# Altium schematic sheet Nixies.SchDoc(Nixies)
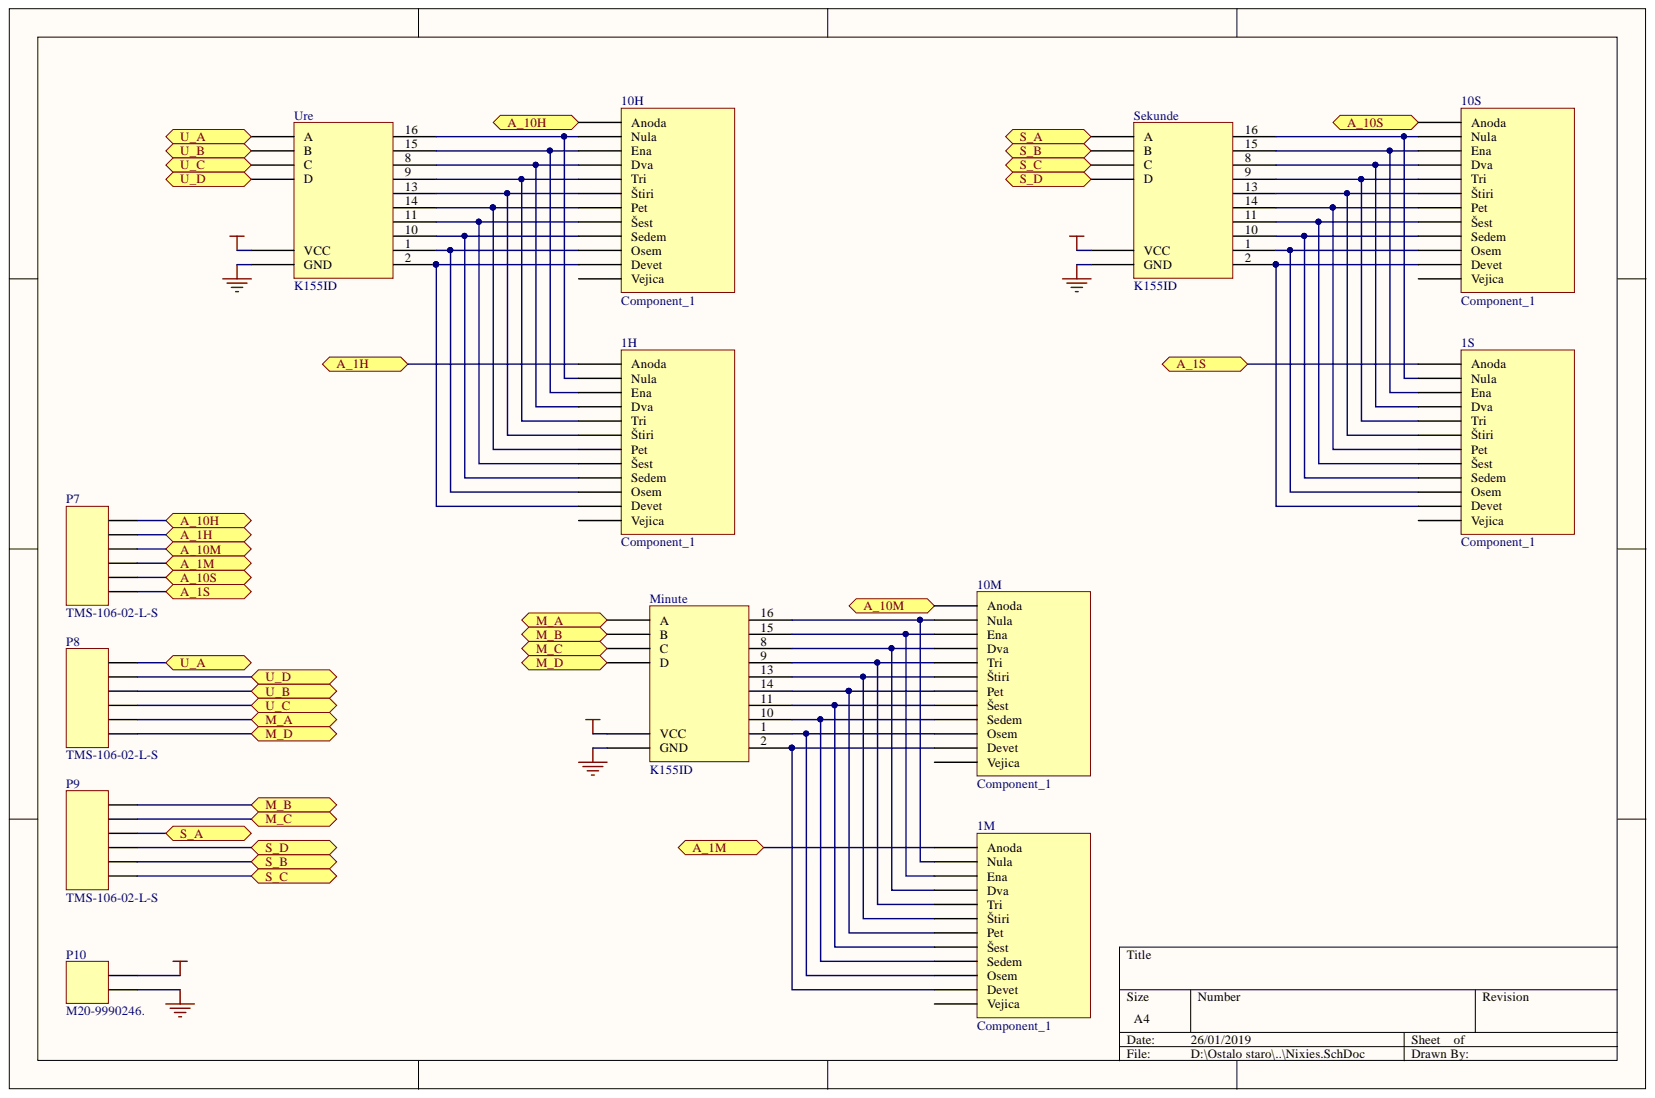
[diagram p0: Nixies.SchDoc]

## Parts
1H  Component_1  [nixie]
1M  Component_1  [nixie]
1S  Component_1  [nixie]
10H  Component_1  [nixie]
10M  Component_1  [nixie]
10S  Component_1  [nixie]
Minute  K155ID  [K155ID]
P7  TMS-106-02-L-S  [HDR 1x6 1.27 M]
P8  TMS-106-02-L-S  [HDR 1x6 1.27 M]
P9  TMS-106-02-L-S  [HDR 1x6 1.27 M]
P10  M20-9990246.  [HDR 1X2 2.54 M]
Sekunde  K155ID  [K155ID]
Ure  K155ID  [K155ID]

## Ports
A_1H, A_1M, A_1S, A_10H, A_10M, A_10S, M_A, M_B, M_C, M_D, S_A, S_B, S_C, S_D, U_A, U_B, U_C, U_D

## Nets
5V: Minute.5(VCC) P10.1 Sekunde.5(VCC) Ure.5(VCC)
GND: Minute.12(GND) P10.2 Sekunde.12(GND) Ure.12(GND)
A_1H: 1H.1(Anoda) P7.2 ; port A_1H
A_1M: 1M.1(Anoda) P7.4 ; port A_1M
A_1S: 1S.1(Anoda) P7.6 ; port A_1S
A_10H: 10H.1(Anoda) P7.1 ; port A_10H
A_10M: 10M.1(Anoda) P7.3 ; port A_10M
A_10S: 10S.1(Anoda) P7.5 ; port A_10S
M_A: Minute.3(A) P8.5 ; port M_A
M_B: Minute.6(B) P9.1 ; port M_B
M_C: Minute.7(C) P9.2 ; port M_C
M_D: Minute.4(D) P8.6 ; port M_D
S_A: P9.3 Sekunde.3(A) ; port S_A
S_B: P9.5 Sekunde.6(B) ; port S_B
S_C: P9.6 Sekunde.7(C) ; port S_C
S_D: P9.4 Sekunde.4(D) ; port S_D
U_A: P8.1 Ure.3(A) ; port U_A
U_B: P8.3 Ure.6(B) ; port U_B
U_C: P8.4 Ure.7(C) ; port U_C
U_D: P8.2 Ure.4(D) ; port U_D
Net1H_2: 1H.2(Nula) 10H.2(Nula) Ure.16
Net1H_3: 1H.3(Devet) 10H.3(Devet) Ure.2
Net1H_4: 1H.4(Osem) 10H.4(Osem) Ure.1
Net1H_5: 1H.5(Sedem) 10H.5(Sedem) Ure.10
Net1H_6: 1H.6(Šest) 10H.6(Šest) Ure.11
Net1H_7: 1H.7(Pet) 10H.7(Pet) Ure.14
Net1H_8: 1H.8(Štiri) 10H.8(Štiri) Ure.13
Net1H_9: 1H.9(Tri) 10H.9(Tri) Ure.9
Net1H_10: 1H.10(Dva) 10H.10(Dva) Ure.8
Net1H_11: 1H.11(Ena) 10H.11(Ena) Ure.15
Net1M_2: 1M.2(Nula) 10M.2(Nula) Minute.16
Net1M_3: 1M.3(Devet) 10M.3(Devet) Minute.2
Net1M_4: 1M.4(Osem) 10M.4(Osem) Minute.1
Net1M_5: 1M.5(Sedem) 10M.5(Sedem) Minute.10
Net1M_6: 1M.6(Šest) 10M.6(Šest) Minute.11
Net1M_7: 1M.7(Pet) 10M.7(Pet) Minute.14
Net1M_8: 1M.8(Štiri) 10M.8(Štiri) Minute.13
Net1M_9: 1M.9(Tri) 10M.9(Tri) Minute.9
Net1M_10: 1M.10(Dva) 10M.10(Dva) Minute.8
Net1M_11: 1M.11(Ena) 10M.11(Ena) Minute.15
Net1S_2: 1S.2(Nula) 10S.2(Nula) Sekunde.16
Net1S_3: 1S.3(Devet) 10S.3(Devet) Sekunde.2
Net1S_4: 1S.4(Osem) 10S.4(Osem) Sekunde.1
Net1S_5: 1S.5(Sedem) 10S.5(Sedem) Sekunde.10
Net1S_6: 1S.6(Šest) 10S.6(Šest) Sekunde.11
Net1S_7: 1S.7(Pet) 10S.7(Pet) Sekunde.14
Net1S_8: 1S.8(Štiri) 10S.8(Štiri) Sekunde.13
Net1S_9: 1S.9(Tri) 10S.9(Tri) Sekunde.9
Net1S_10: 1S.10(Dva) 10S.10(Dva) Sekunde.8
Net1S_11: 1S.11(Ena) 10S.11(Ena) Sekunde.15

Not connected: 1H 12(Vejica); 1M 12(Vejica); 1S 12(Vejica); 10H 12(Vejica); 10M 12(Vejica); 10S 12(Vejica)

## Library data of the parts
Minute Sekunde Ure = Component_1: Library Name: K155iD.SchLib; Component Kind: Standard
P7-P9 = TMS-106-02-L-S: HEADER, 1.27MM, THT VERT, 6WAY; Manufacturer: SAMTEC; SVHC: No SVHC (15-Jun-2015); Product Range: TMS Series; Pitch Spacing: 1.27; No. of Rows: 1; No. of Contacts: 6; Contact Termination Type: Through Hole; Contact Termination: Through Hole Vertical<!--FANDB--><div class="features-and-benefits-container"><div class="features-and-benefits-description"><span class="features-benefits-value">The TMS series from Samtec are standard micro terminal strip headers. These are unshrouded through hole headers with 0.018" (0.46mm) square post and mates with Samtec SMS, SLM and RSM series of connectors.</span></div><div class="features-and-benefits-list"><ul class="features-and-benefits-list-list"><li class="features-and-benefits-list-item">Orderable with single/dual rows (-S/-D options)</li><li class="features-and-benefits-list-item">Pitch spacing is 1.27mm (1 to 50 contacts per row) with straight orientation</li><li class="features-and-benefits-list-item">High operating temperature range of -55°C to 125°C for 10?" gold plated contacts</li><li class="features-and-benefits-list-item">Optional polarization for single or double row design up to 100 pins</li><li class="features-and-benefits-list-item">Contact material is phosphor bronze</li><li class="features-and-benefits-list-item">Black liquid crystal polymer insulator material</li><li class="features-and-benefits-list-item">Complies with UL E111594 standard</li></ul></div><div class="features-and-benefits-applications"><ul class="features-and-benefits-value"><li class="features-and-benefits-list-item">Industrial</li></ul></div></div>; Supplier Part Number 1: 2054934; Supplier 1: Farnell; Stock 1: 200; RoHS: YES; Pricing 1: 25=0,64, 50=0,6, 100=0,55, 500=0,45, 1000=0,42, 5000=0,32, 10000=0,3 (EUR); Manufacturer Part Number: TMS-106-02-L-S; Library Name: Connectors.IntLib; Gender: Header; Contact Plating: Gold Plated Contacts; Contact Material: Phosphor Bronze; ComponentLink1URL: http://www.farnell.com/datasheets/1847335.pdf; ComponentLink1Description: http://www.farnell.com/datasheets/1847335.pdf; Component Kind: Standard
P10 = M20-9990246.: HEADER, STRAIGHT, 2.54MM 1ROW, 2WAY; Manufacturer: HARWIN; Supplier Part Number 1: 1022247; Supplier 1: Farnell; Stock 1: 41292; RoHS: YES; Pricing 1: 10=0,0618, 50=0,0562, 100=0,0485, 250=0,0468, 500=0,0353, 1000=0,032, 2500=0,0251, 5000=0,0245 (EUR); Manufacturer Part Number: M20-9990246.; Library Name: Connectors.IntLib; ComponentLink3URL: http://www.harwin.com/include/downloads/drawings/M20-999.PDF; ComponentLink3Description: http://www.harwin.com/include/downloads/drawings/M20-999.PDF; ComponentLink2URL: http://www.farnell.com/cad/315376.pdf; ComponentLink2Description: http://www.farnell.com/cad/315376.pdf; ComponentLink1URL: http://www.farnell.com/datasheets/1089605.pdf; ComponentLink1Description: http://www.farnell.com/datasheets/1089605.pdf; Component Kind: Standard
1H 1M 1S 10H 10M 10S = Component_1: Library Name: nixie.SchLib; Component Kind: Standard
